# Altium schematic sheet mpc57_cwconn.SchDoc(mpc57_cwconn)
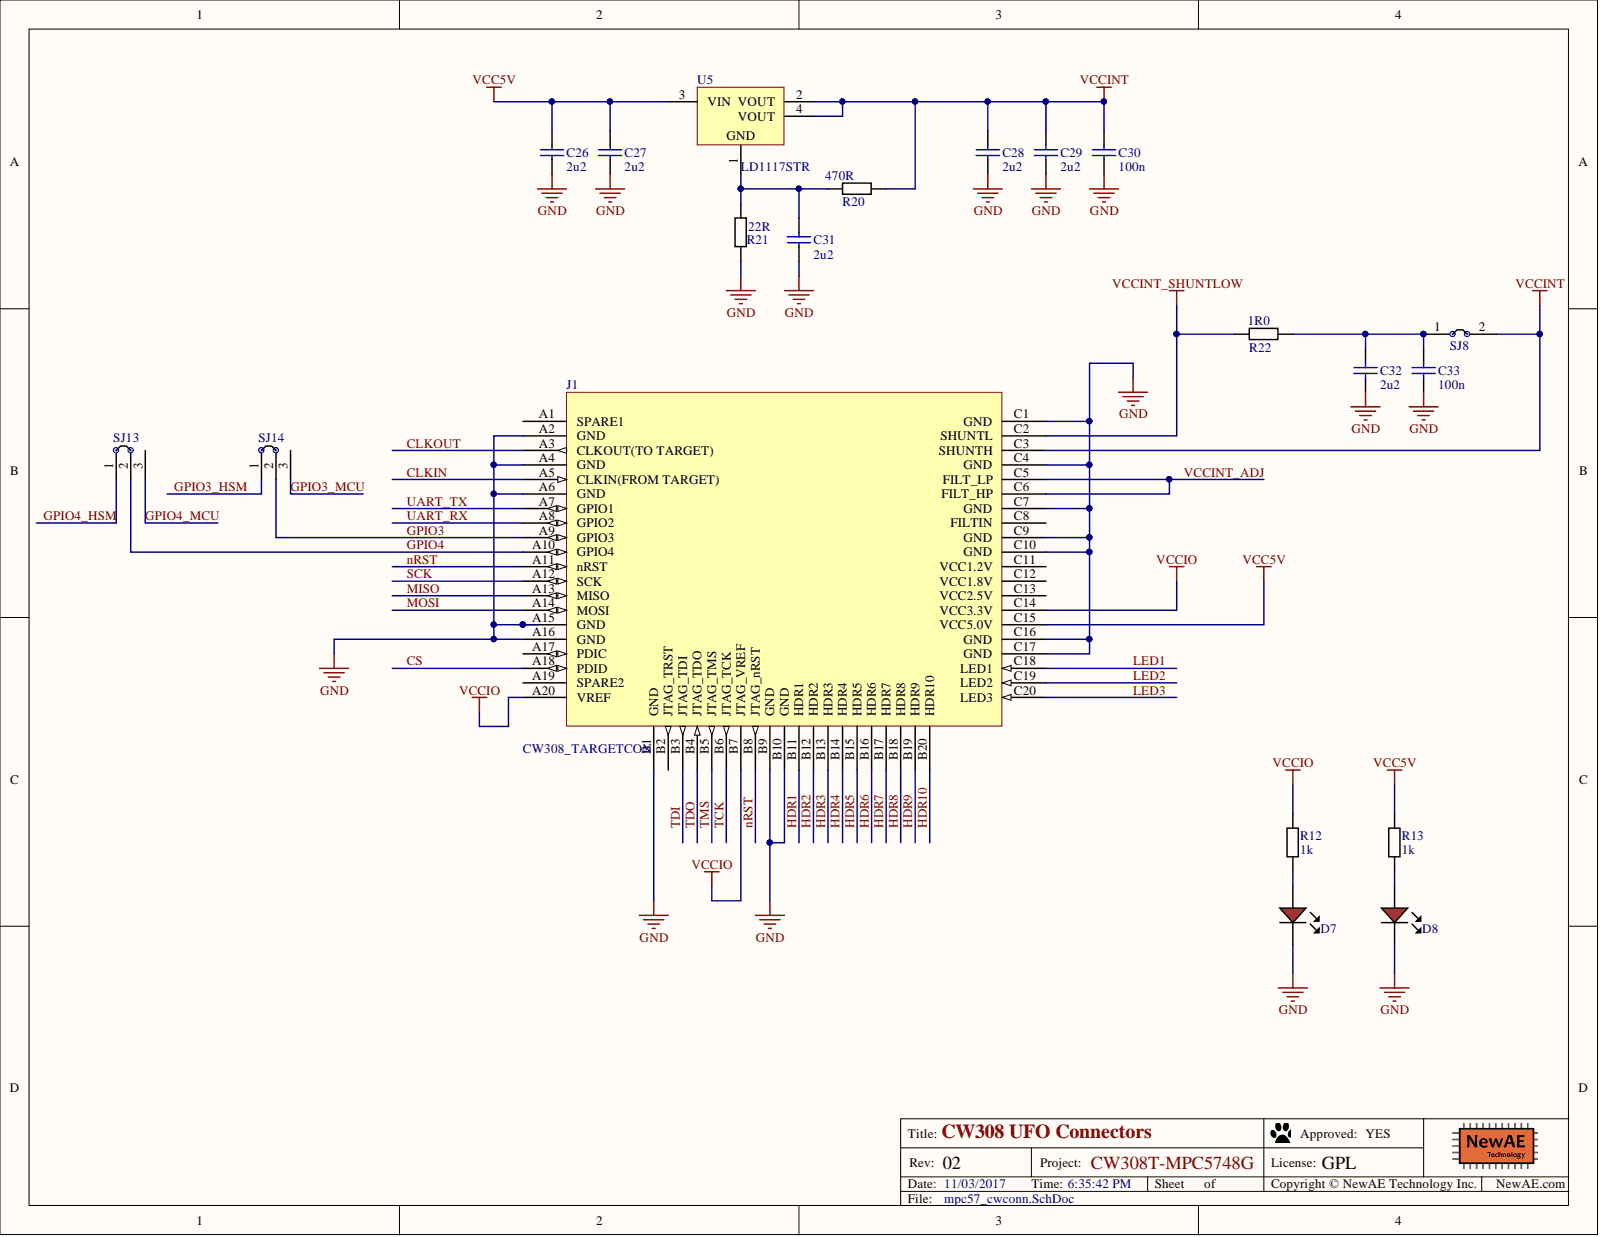
[diagram p0: mpc57_cwconn.SchDoc]

## Parts
C26  2u2  [0603]
C27  2u2  [0603]
C28  2u2  [0603]
C29  2u2  [0603]
C30  100n  [0402]
C31  2u2  [0603]
C32  2u2  [0603]
C33  100n  [0402]
D7  LED, 0603, Red  [LED-0603-RED]  (value not drawn)
D8  LED, 0603, Red  [LED-0603-RED]  (value not drawn)
J1  CW308_TARGETCON  [CW308_TBOARD_CONN]
R12  1k  [0603]
R13  1k  [0603]
R20  470R  [0603]
R21  22R  [0603]
R22  1R0  [0805]
SJ8  NC  [SJ_2S_NC]  (value not drawn)  (no BOM)
SJ13  NC  [SJ_3S_NC12]  (value not drawn)  (no BOM)
SJ14  NC  [SJ_3S_NC12]  (value not drawn)  (no BOM)
U5  LD1117STR  [SOT-223_L]

## Nets
GND: C26.2 C27.2 C28.2 C29.2 C30.2 C31.2 C32.2 C33.2 D7.K D8.K J1.A2(GND) J1.A4(GND) J1.A6(GND) J1.A15(GND) J1.A16(GND) J1.B1(GND) J1.B9(GND) J1.B10(GND) J1.C1(GND) J1.C4(GND) J1.C7(GND) J1.C9(GND) J1.C10(GND) J1.C16(GND) J1.C17(GND) R21.2
VCC5V: C26.1 C27.1 J1.C15(VCC5.0V) R13.1 U5.3(VIN)
VCCINT: C28.1 C29.1 C30.1 J1.C3(SHUNTH) R20.1 SJ8.2 U5.2(VOUT) U5.4(VOUT)
VCCINT_SHUNTLOW: J1.C2(SHUNTL) R22.1
VCCIO: J1.A20(VREF) J1.B7(JTAG_VREF) J1.C14(VCC3.3V) R12.1
CLKIN: J1.A5(CLKIN(FROM_TARGET))
CLKOUT: J1.A3(CLKOUT(TO_TARGET))
CS: J1.A18(PDID)
GPIO3: J1.A9(GPIO3) SJ14.2
GPIO3_HSM: SJ14.1
GPIO3_MCU: SJ14.3
GPIO4: J1.A10(GPIO4) SJ13.2
GPIO4_HSM: SJ13.1
GPIO4_MCU: SJ13.3
HDR1: J1.B11(HDR1)
HDR2: J1.B12(HDR2)
HDR3: J1.B13(HDR3)
HDR4: J1.B14(HDR4)
HDR5: J1.B15(HDR5)
HDR6: J1.B16(HDR6)
HDR7: J1.B17(HDR7)
HDR8: J1.B18(HDR8)
HDR9: J1.B19(HDR9)
HDR10: J1.B20(HDR10)
LED1: J1.C18(LED1)
LED2: J1.C19(LED2)
LED3: J1.C20(LED3)
MISO: J1.A13(MISO)
MOSI: J1.A14(MOSI)
nRST: J1.A11(nRST) J1.B8(JTAG_nRST)
SCK: J1.A12(SCK)
TCK: J1.B6(JTAG_TCK)
TDI: J1.B3(JTAG_TDI)
TDO: J1.B4(JTAG_TDO)
TMS: J1.B5(JTAG_TMS)
UART_RX: J1.A8(GPIO2)
UART_TX: J1.A7(GPIO1)
VCCINT_ADJ: J1.C5(FILT_LP) J1.C6(FILT_HP)
NetC31_1: C31.1 R20.2 R21.1 U5.1
NetC32_1: C32.1 C33.1 R22.2 SJ8.1
NetD7_A: D7.A R12.2
NetD8_A: D8.A R13.2

Not connected: J1 A1(SPARE1) A17(PDIC) A19(SPARE2) B2(JTAG_TRST) C8(FILTIN) C11(VCC1.2V) C12(VCC1.8V) C13(VCC2.5V)

## Library data of the parts
C26-C29 C31 C32 = CCHIP-2u2-0603: 2u2, 0603; Database Table Name: main; Case-Metric: 1608; Value: 2u2; Pin Count: 2; Part Number: CCHIP-2u2-0603; Mounting Technology: SMT; Library Name: NewAE_AltiumLib.DBLib; Component Type: Standard; Component Kind: Standard; Case-EIA: 0603
C30 C33 = CCHIP-100n-0402: 100n, 0402; Database Table Name: main; Value: 100n; Pin Count: 2; Part Number: CCHIP-100n-0402; Mounting Technology: SMT; Library Name: NewAE_AltiumLib.DBLib; Component Type: Standard; Component Kind: Standard; Case-EIA: 0402
D7 D8 = LEDCHIP_0603_RED: Chip LED, Red; Database Table Name: main; Pin Count: 2; Part Number: LEDCHIP_0603_RED; Mounting Technology: SMT; Library Name: NewAE_AltiumLib.DBLib; Component Type: Standard; Component Kind: Standard
J1 = CW308_TBOARD: CW308 "Standard" Target Board Connector; Component Kind: Standard; Library Name: ChipWhisperer_AltiumLib.SchLib
R12 R13 = RCHIP-1K-0603: 1k res, 0603, 1%, 1/10W; Manufacture Part Number 1: RC0603FR-071KL; Manufacture 1: Yageo; Rated Voltage: 75VDC; Rated Power: 0.1W; Database Table Name: main; Case-Metric: 1608; Value: 1k; Tolerance: 1%; Temperature Range: -55C to 155C; Supplier Part Number 1: 311-1.00KHRCT-ND; Supplier 1: Digi-Key; Pin Count: 2; Part Number: RCHIP-1K-0603; Mounting Technology: SMT; Library Name: NewAE_AltiumLib.DBLib; Component Type: Standard; Component Kind: Standard; Case-EIA: 0603
R20 = RCHIP-120R-0603: 470R res, 0603, 1%, 1/10W; Manufacture Part Number 1: RC0603FR-07470RL; Manufacture 1: Yageo; Rated Voltage: 75VDC; Rated Power: 0.1W; Database Table Name: main; Case-Metric: 1608; Value: 470R; Tolerance: 1%; Temperature Range: -55C to 155C; Supplier Part Number 1: 311-470HRCT-ND; Supplier 1: Digi-Key; Pin Count: 2; Part Number: RCHIP-120R-0603; Mounting Technology: SMT; Library Name: NewAE_AltiumLib.DBLib; Component Type: Standard; Component Kind: Standard; Case-EIA: 0603
R21 = RCHIP-120R-0603: 120R res, 0603, 1%, 1/10W; Manufacture Part Number 1: RC0603FR-0722RL; Manufacture 1: Yageo; Rated Voltage: 75VDC; Rated Power: 0.1W; Database Table Name: main; Case-Metric: 1608; Value: 22R; Tolerance: 1%; Temperature Range: -55C to 155C; Supplier Part Number 1: 311-22HRCT-ND; Supplier 1: Digi-Key; Pin Count: 2; Part Number: RCHIP-120R-0603; Mounting Technology: SMT; Library Name: NewAE_AltiumLib.DBLib; Component Type: Standard; Component Kind: Standard; Case-EIA: 0603
R22 = RCHIP-1R0-0805: 1R0 res, 0805, 1%, 1/8W; Manufacture Part Number 1: RC0805FR-071RL; Manufacture 1: Yageo; Rated Voltage: 75VDC; Rated Power: 0.125W; Database Table Name: main; Value: 1R0; Tolerance: 1%; Temperature Range: -55C to 155C; Supplier Part Number 1: 311-1.00CRCT-ND; Supplier 1: Digi-Key; Pin Count: 2; Part Number: RCHIP-1R0-0805; Mounting Technology: SMT; Library Name: NewAE_AltiumLib.DBLib; Component Type: Standard; Component Kind: Standard; Case-EIA: 0805
SJ8 = SJ_2S: Solder Jumper, NC; Database Table Name: main; Pin Count: 2; Part Number: SJ_2S; Library Name: NewAE_AltiumLib.DBLib; Component Type: Standard (No BOM)
SJ13 SJ14 = SJ_3S_12JMP: Solder Jumper, NC; Database Table Name: main; Component Group: PCB Feature; Pin Count: 3; Part Number: SJ_3S_12JMP; Mounting Technology: COPPER; Library Name: NewAE_AltiumLib.DBLib; Component Type: Standard (No BOM)
U5 = CMP-0244-00313-1: Adjustable Low Drop Voltage Regulator, 1.25 to 15V, 4-Pin SOT-223, Tape and Reel; Supplier Part Number 1: 497-1244-1-ND; Supplier 1: Digi-Key; PackageVersion: 2010; PackageReference: SOT-223; PackageDescription: 4-Pin SOT-223 Package, 6.5 x 3.5 mm Body, 2.3 mm Pitch, 7 mm Lead Span; Library Name: Altium Content Vault; DatasheetVersion: 1/11/2011; ComponentLink2URL: http://www.st.com/internet/com/TECHNICAL_RESOURCES/TECHNICAL_LITERATURE/DATASHEET/CD00000544.pdf; ComponentLink2Description: Datasheet; ComponentLink1URL: http://www.st.com; ComponentLink1Description: Manufacturer URL; Component Kind: Standard
